# Altium schematic sheet MQ7.SchDoc
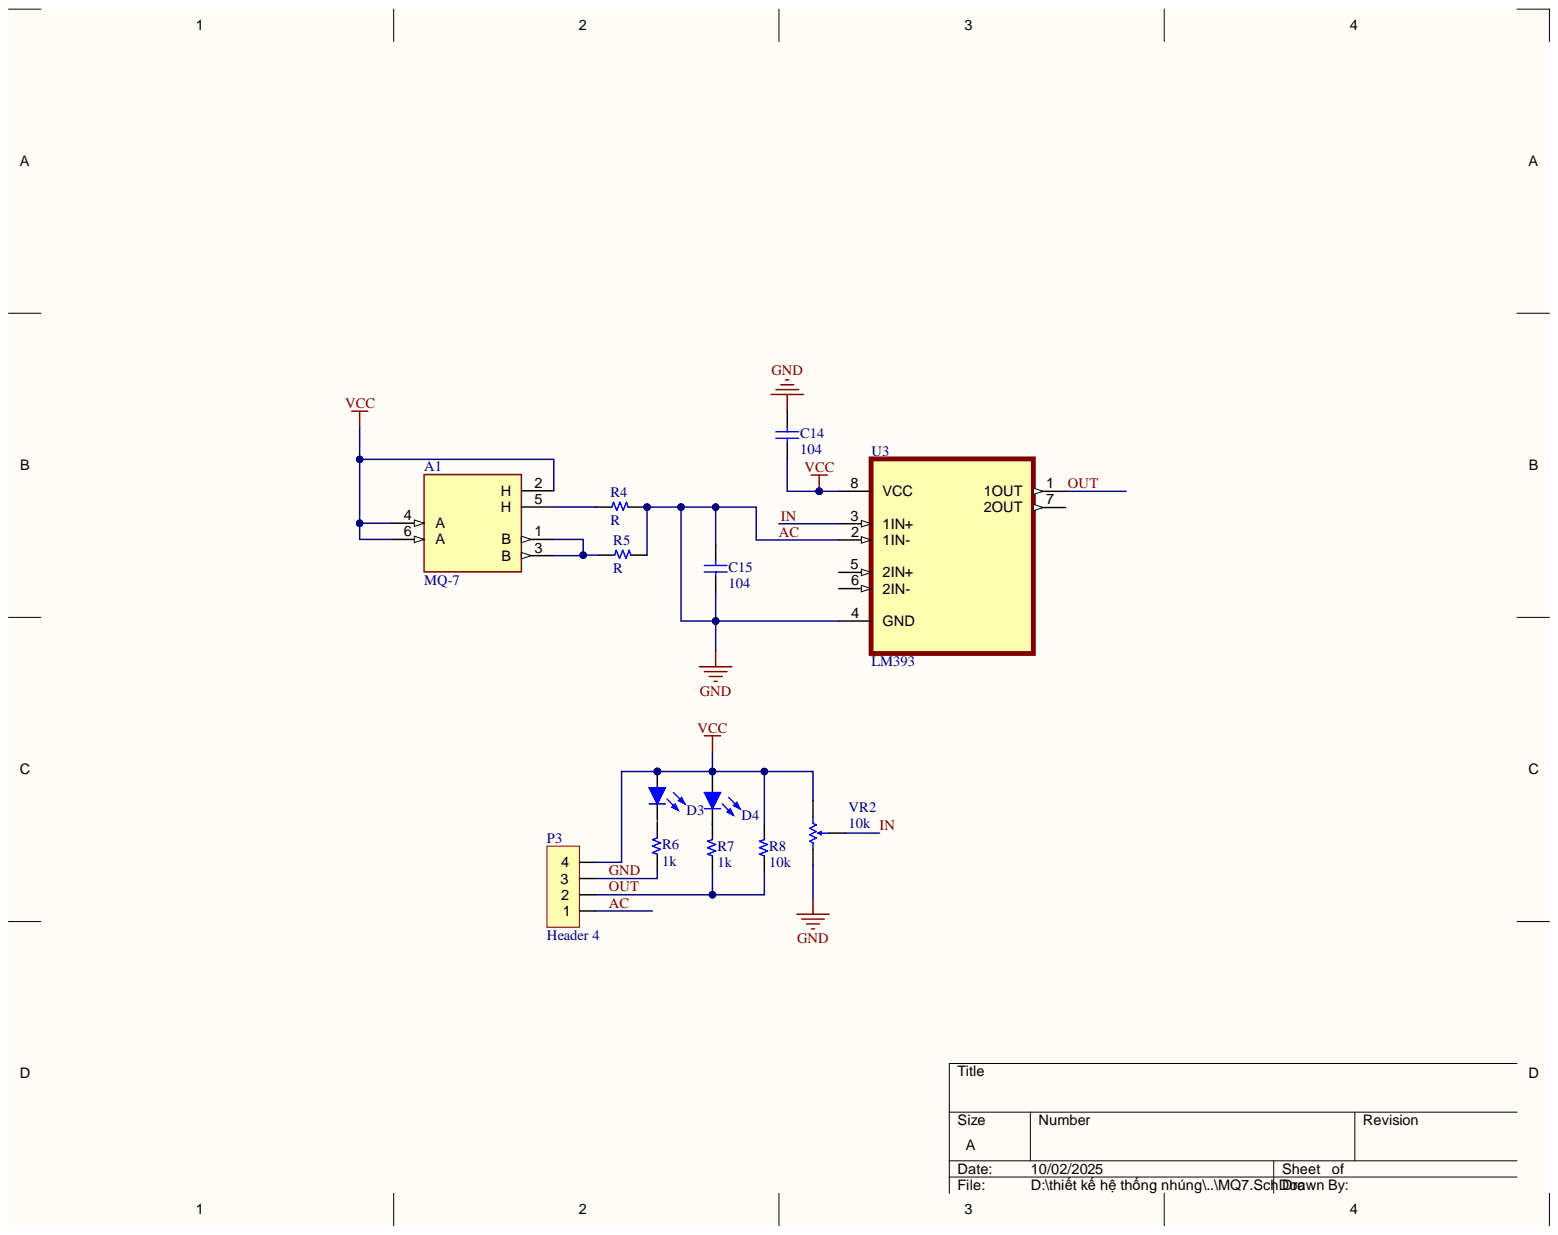
[diagram p0: MQ7.SchDoc]

## Parts
A1  MQ-7  [XDCR_MQ-7]
C14  104  [C 0603]
C15  104  [C 0603]
D3  Led  [Led 0603 - red]  (value not drawn)
D4  Led  [Led 0603 - red]  (value not drawn)
P3  Header 4  [HDR/Header/4]
R4  R  [R 0603]
R5  R  [R 0603]
R6  1k  [R 0603]
R7  1k  [R 0603]
R8  10k  [R 0603]
U3  LM393  [SOIC127P600X175-8N]
VR2  10k  [R VAR B]

## Nets
VCC: A1.2(H) A1.4(A) A1.6(A) C14.2 D3.1 D4.1 P3.4 R8.2 U3.8(VCC) VR2.1
AC: C14.1 C15.1 C15.2 P3.1 P3.3 R4.2 R5.2 R6.1 U3.2(1IN-) U3.4(GND) VR2.3
IN: U3.3(1IN+) VR2.2
OUT: P3.2 R7.1 R8.1 U3.1(1OUT)
NetA1_1: A1.1(B) A1.3(B) R5.1
NetA1_5: A1.5(H) R4.1

Not connected: D3 2; D4 2; R6 2; R7 2; U3 5(2IN+) 6(2IN-) 7(2OUT)

## Library data of the parts
A1 = MQ-7: Gas Sensor, High sensitivity to carbon monoxide; MF: Hanwei Electronics Co., Ltd; Check_prices: https://www.snapeda.com/parts/MQ-7/Hanwei+Electronics+Co.%252C+Ltd/view-part/?ref=eda; Component Kind: Standard; Library Name: MQ-7.IntLib; MP: MQ-7; Package: Package; SnapEDA_Link: https://www.snapeda.com/parts/MQ-7/Hanwei+Electronics+Co.%252C+Ltd/view-part/?ref=snap
C14 C15 = Capacitor NP: Capacitor non-pol; Component Kind: Standard; Library Name: chipfc_libs.SchLib
D3 D4 = Led: Typical LED; Component Kind: Standard; Library Name: led.SchLib
P3 = Header 4: Header, 4-Pin; Component Kind: Standard; Library Name: connectors.SchLib
R4-R8 = Resistor: Component Kind: Standard; Description: Resistor; Library Name: chipfc_libs.SchLib
U3 = LM393D: Comparator General Purpose CMOS, DTL, ECL, MOS, Open-Collector, TTL 8-SOIC; MF: STMicroelectronics; Check_prices: https://www.snapeda.com/parts/LM393D/STMicroelectronics/view-part/?ref=eda; Component Kind: Standard; Library Name: LM393D.IntLib; MP: LM393D; Package: SOIC-8 STMicroelectronics; SnapEDA_Link: https://www.snapeda.com/parts/LM393D/STMicroelectronics/view-part/?ref=snap
VR2 = Resistor Variable: Component Kind: Standard; Description: Resistor Variable; Library Name: chipfc_libs.SchLib
